# Altium schematic sheet Nguon_MIC_Sch.SchDoc
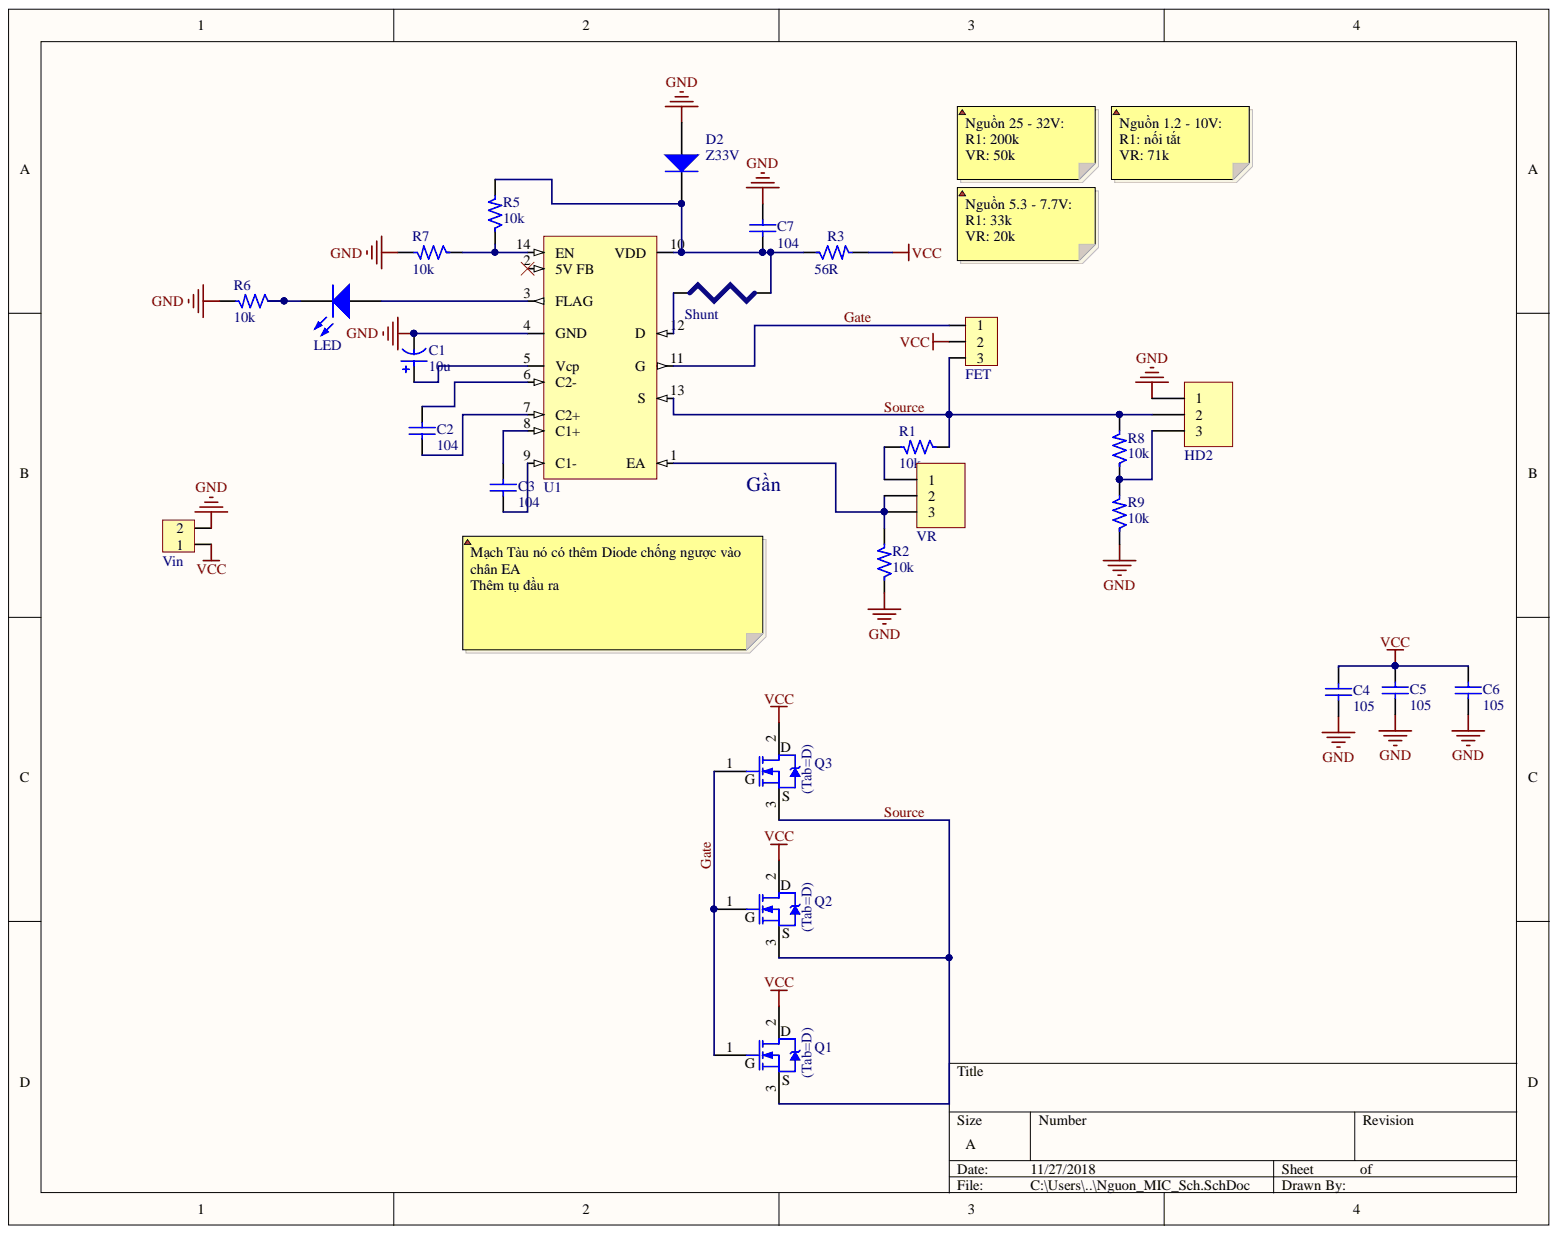
[diagram p0: Nguon_MIC_Sch.SchDoc]

## Parts
C1  value 10u  [C5mm]  (hidden comment: Cap Pol1)
C2  value 104  [CN1.5]  (hidden comment: Cap)
C3  value 104  [CN1.5]  (hidden comment: Cap)
C4  value 105  [CN1.5]  (hidden comment: Cap)
C5  value 105  [CN1.5]  (hidden comment: Cap)
C6  value 105  [CN1.5]  (hidden comment: Cap)
C7  value 104  [CN1.5]  (hidden comment: Cap)
D2  Z33V  [1N4148]
FET  Header 3  [Header 3 - 150mil]  (value not drawn)
HD2  Header 3  [BIG_Header 3]  (value not drawn)
LED  LED SMD  [LED_0805_Blue]  (value not drawn)
Q1  IRF3205  [TO-220]  (value not drawn)
Q2  IRF3205  [TO-220]  (value not drawn)
Q3  IRF3205  [TO-220]  (value not drawn)
R1  Resistance (Ohms): 10k  [SM-0805]  (hidden comment: #NAME?)
R2  Resistance (Ohms): 10k  [SM-0805]  (hidden comment: #NAME?)
R3  Resistance (Ohms): 56R  [R2W]  (hidden comment: #NAME?)
R5  Resistance (Ohms): 10k  [SM-0805]  (hidden comment: #NAME?)
R6  Resistance (Ohms): 10k  [SM-0805]  (hidden comment: #NAME?)
R7  Resistance (Ohms): 10k  [SM-0805]  (hidden comment: #NAME?)
R8  Resistance (Ohms): 10k  [SM-0805]  (hidden comment: #NAME?)
R9  Resistance (Ohms): 10k  [SM-0805]  (hidden comment: #NAME?)
Shunt  Shunt  [Shunt 5mR]
U1  MIC5158  [SOIC14]  (value not drawn)
Vin  Header 2  [HEAD2T]  (value not drawn)
VR  Header 3  [BIG_Header 3]  (value not drawn)

## Nets
GND: C1.2 C4.1 C5.1 C6.1 C7.2 D2.1 HD2.1 R2.1 R6.2 R7.2 R9.1 U1.4(GND) Vin.2
VCC: C4.2 C5.2 C6.2 FET.2 Q1.2 Q2.2 Q3.2 R3.2 Vin.1
Gate: FET.1 Q1.1 Q2.1 Q3.1 U1.11(G)
Source: FET.3 HD2.2 Q1.3 Q2.3 Q3.3 R1.1 R8.2 U1.13(S)
NetC1_1: C1.1 U1.5(Vcp)
NetC2_1: C2.1 U1.7(C2+)
NetC2_2: C2.2 U1.6(C2-)
NetC3_1: C3.1 U1.9(C1-)
NetC3_2: C3.2 U1.8(C1+)
NetC7_1: C7.1 D2.2 R3.1 R5.2 Shunt.1 U1.10(VDD)
NetHD2_3: HD2.3 R8.1 R9.2
NetLED_1: LED.1 U1.3(FLAG)
NetLED_2: LED.2 R6.1
NetR1_2: R1.2 VR.1
NetR2_2: R2.2 U1.1(EA) VR.2 VR.3
NetR5_1: R5.1 R7.1 U1.14(EN)
NetShunt_2: Shunt.2 U1.12(D)

Not connected: U1 2(5V_FB)

## Library data of the parts
C1 = Cap Pol: Component Kind: Standard; Description: Cap pol; LatestRevisionDate: 17-Jul-2002; LatestRevisionNote: Re-released for DXP Platform.; PackageDescription: Polarized Capacitor (Radial); 2 Leads; PackageReference: RB7.6-15; Published: 8-Jun-2000; Library Name: hienclubvn.IntLib; Publisher: Altium Limited
C2-C7 = Cap: Capacitor; Component Kind: Standard; LatestRevisionDate: 17-Jul-2002; LatestRevisionNote: Re-released for DXP Platform.; PackageDescription: Radial Cap, Thru-Hole; 2 Leads; 0.3 in Pin Spacing; PackageReference: RAD-0.3; Published: 8-Jun-2000; Library Name: hienclubvn.IntLib; Publisher: Altium Limited
D2 = Diode: Default Diode; Code_JEDEC: DO-214-AB; Component Kind: Standard; LatestRevisionDate: 17-Jul-2002; LatestRevisionNote: Re-released for DXP Platform.; PackageDescription: DO-214-AB/SMC; 2 C-Bend Leads; Body 7.9 x 5.9 mm, inc. leads (LxW); PackageReference: SMC; PackageVersion: Sep-1996; Published: 8-Jun-2000; Library Name: hienclubvn.IntLib; Publisher: Altium Limited
HD2 VR = Header 3: Header, 3-Pin; Component Kind: Standard; LatestRevisionDate: 17-Jul-2002; LatestRevisionNote: Re-released for DXP Platform.; Library Name: hienclubvn.IntLib; Publisher: Altium Limited
LED = LED SMD: LED; Component Kind: Standard; Library Name: PIF_Library.IntLib
Q1-Q3 = IRF3205: HEXFET N-Channel Power MOSFET; Component Kind: Standard; Library Name: HIENCLUBVN_Library.IntLib
R1 R2 R5-R9 = RES 0805: RES SMD 10K OHM 5% 1/8W 0805; Manufacturer: Stackpole Electronics Inc.; Manufacturer Part Number: RMCF0805JT10K0; Power (Watts): 0.125W, 1/8W; Temperature Coefficient: ±200ppm/°C; Category: Resistors; Component Kind: Standard; ComponentLink1Description: http://www.seielect.com/Catalog/SEI-RMCF_RMCP.pdf; ComponentLink1URL: http://www.seielect.com/Catalog/SEI-RMCF_RMCP.pdf; Composition: Thick Film; Features: Automotive AEC-Q200; Height: 0.026" (0.65mm); Number of Terminations: 2; Operating Temperature: -55°C ~ 155°C; Package / Case: 0805 (2012 Metric); Packaging: Tape & Reel (TR); Pricing 1: 5000=0.0019, 10000=0.00165, 25000=0.00145, 50000=0.00133, 125000=0.0013 (USD); RoHS: RoHS Compliant; Size / Dimension: 0.079" L x 0.049" W (2.00mm x 1.25mm); Stock 1: 965000; Supplier 1: Digi-Key; Supplier Device Package: 0805; Supplier Part Number 1: RMCF0805JT10K0TR-ND; Tolerance: ±5%; Library Name: HIENCLUBVN_Library.IntLib
R3 = RES DIP: RES DIP 10K OHM 5% 1/4W; Manufacturer: Stackpole Electronics Inc.; Manufacturer Part Number: RMCF0805JT10K0; Power (Watts): 0.125W, 1/8W; Temperature Coefficient: ±200ppm/°C; Category: Resistors; Component Kind: Standard; ComponentLink1Description: http://www.seielect.com/Catalog/SEI-RMCF_RMCP.pdf; ComponentLink1URL: http://www.seielect.com/Catalog/SEI-RMCF_RMCP.pdf; Composition: Thick Film; Features: Automotive AEC-Q200; Height: 0.026" (0.65mm); Number of Terminations: 2; Operating Temperature: -55°C ~ 155°C; Package / Case: 0805 (2012 Metric); Packaging: Tape & Reel (TR); RoHS: RoHS Compliant; Size / Dimension: 0.079" L x 0.049" W (2.00mm x 1.25mm); Supplier Device Package: 0805; Tolerance: ±5%; Library Name: HIENCLUBVN_Library.IntLib
U1 = MIC5158: Super LDO; Component Kind: Standard; Library Name: TIEP2013.IntLib
Vin = Header 2: Header, 2-Pin; Component Kind: Standard; Value: Header 2; Library Name: hienclubvn.IntLib
FET = Header 3: Component Kind: Standard; Library Name: TIEP2013.IntLib
Shunt = Shunt: Component Kind: Standard; Library Name: TIEP2013.IntLib
